# Altium schematic sheet drvoutput4.SchDoc(drvoutput4)
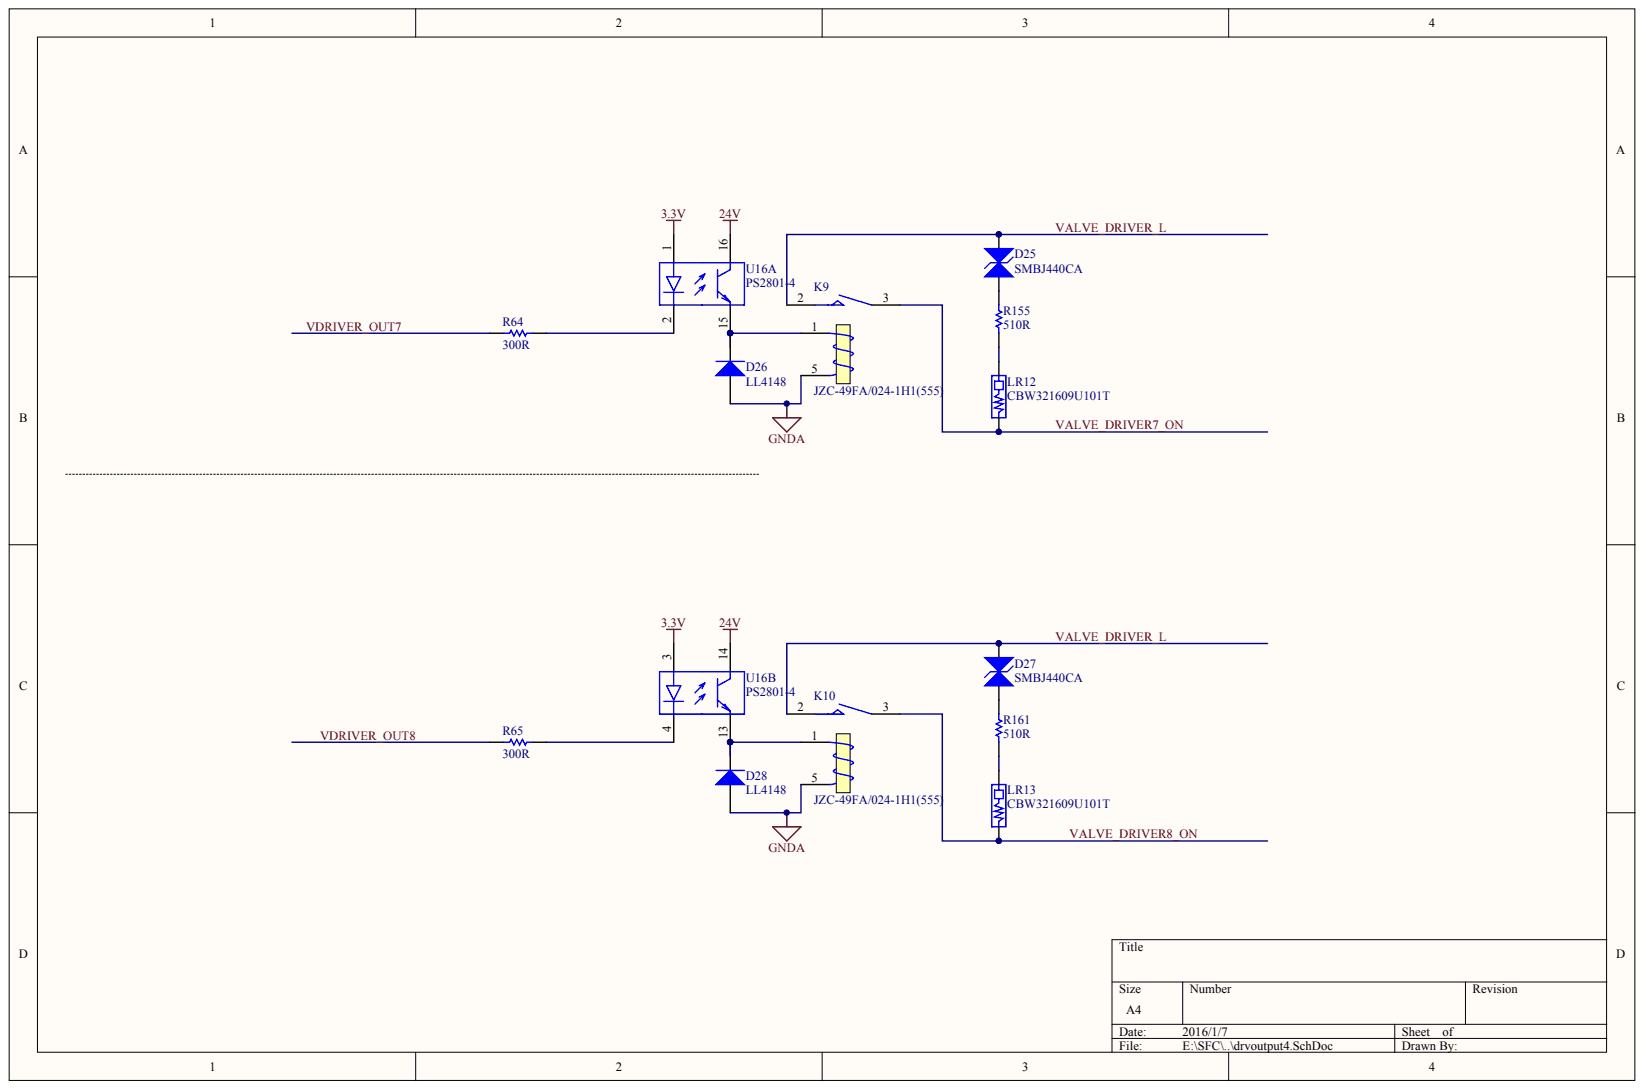
[diagram p0: drvoutput4.SchDoc]

## Parts
D25  SMBJ440CA
D26  LL4148
D27  SMBJ440CA
D28  LL4148
K9  JZC-49FA/024-1H1(555)
K10  JZC-49FA/024-1H1(555)
LR12  CBW321609U101T
LR13  CBW321609U101T
R64  300R
R65  300R
R155  510R
R161  510R
U16  PS2801-4  (parts A,B)

## Nets
3.3V: U16.1 U16.3
24V: U16.14 U16.16
GNDA: D26.1 D28.1 K9.5 K10.5
VALVE_DRIVER7_ON: K9.3 LR12.1
VALVE_DRIVER8_ON: K10.3 LR13.1
VALVE_DRIVER_L: D25.2 D27.2 K9.2 K10.2
VDRIVER_OUT7: R64.1
VDRIVER_OUT8: R65.1
NetD25_1: D25.1 R155.2
NetD26_2: D26.2 K9.1 U16.15
NetD27_1: D27.1 R161.2
NetD28_2: D28.2 K10.1 U16.13
NetLR12_2: LR12.2 R155.1
NetLR13_2: LR13.2 R161.1
NetR64_2: R64.2 U16.2
NetR65_2: R65.2 U16.4

## Library data of the parts
R64 R65 R155 R161 = (no symbol name): Value: 10K; Published: 8-Jun-2000; LatestRevisionDate: 17-Jul-2002; LatestRevisionNote: Re-released for DXP Platform.; PackageReference: AXIAL-0.3; Publisher: Altium Limited
D25-D28 K9 K10 LR12 LR13 U16 = (no symbol name)
  D25 D27: Published: 8-Jun-2000; LatestRevisionDate: 17-Jul-2002; LatestRevisionNote: Re-released for DXP Platform.; PackageReference: DIODE-0.7
  D26 D28: Published: 8-Jun-2000; LatestRevisionDate: 17-Jul-2002; LatestRevisionNote: Re-released for DXP Platform.; DatasheetVersion: 1998; PackageVersion: Aug-1999; PackageReference: DO-41; Code_JEDEC: DO-204-AL; ComponentLink1Description: Manufacturer Link; ComponentLink1URL: http://www.fairchildsemi.com/; ComponentLink2Description: Datasheet; ComponentLink2URL: http://www.fairchildsemi.com/ds/1N%2F1N4001.pdf
  K9 K10: Published: 8-Jun-2000; LatestRevisionDate: 17-Jul-2002; LatestRevisionNote: Re-released for DXP Platform.; PackageReference: MODULE5B
  U16: Published: 28-Feb-2003; LatestRevisionDate: 13-Apr-2006; LatestRevisionNote: IPC-7351 Footprint Added.; DatasheetVersion: Jan-2002, Rev. 7; PackageVersion: rev7, Jan-2002; PackageReference: 751-07; PackageDescription: 8-Pin Plastic Small Outline Integrated Circuit 1.27 mm Pitch; Code_JEDEC: MS-012-AA; Code_IPC: SOIC127P600-8; ComponentLink1Description: Manufacturer Link; ComponentLink1URL: http://www.onsemi.com/; ComponentLink2Description: Datasheet; ComponentLink2URL: http://www.onsemi.com/pub/Collateral/LM358-D.PDF
  D25-D28 K9 K10 U16: Publisher: Altium Limited
